# Altium schematic sheet power.SchDoc
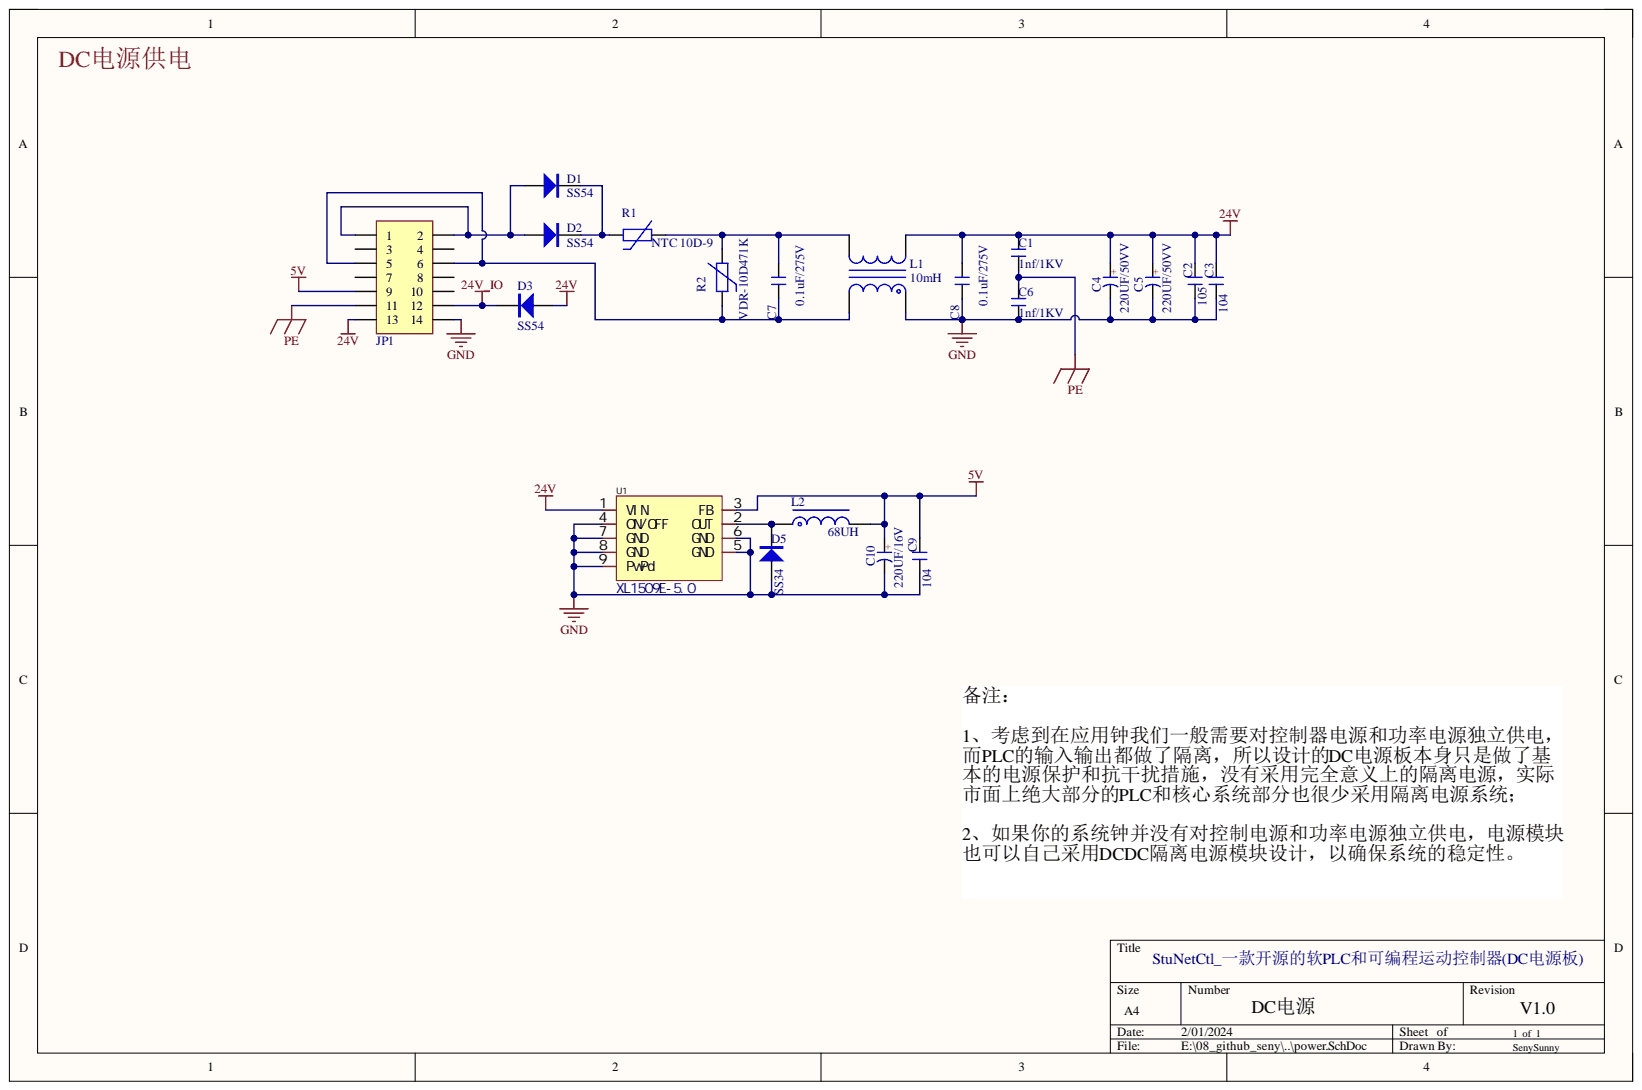
[diagram p0: power.SchDoc]

## Parts
C1  1nf/1KV  [CY 7.5*8*4]
C2  105  [C 0805]
C3  104  [C 0805]
C4  220UF/50VV  [CAP 5.0*10*12.5 - BK]
C5  220UF/50VV  [CAP 5.0*10*12.5 - BK]
C6  1nf/1KV  [CY 7.5*8*4]
C7  0.1uF/275V  [CX 10*13*11]
C8  0.1uF/275V  [CX 10*13*11]
C9  104  [C 0805]
C10  220UF/16V  [CAP 2.5*6.3*11.2 - BK]
D1  SS54  [SMA(DO214AC)]
D2  SS54  [SMA(DO214AC)]
D3  SS54  [SMA(DO214AC)]
D5  SS34  [SMA(DO214AC)]
JP1  Header 2X7  [HDR-TH_14P-P2.54-V-R2-C7-S2.54]  (value not drawn)
L1  10mH  [UU9.8]
L2  68UH  [CDRH127]
R1  NTC 10D-9  [NTC XD-9]
R2  VDR-10D471K  [VDR 10DX]
U1  XL1509E-5.0  [SOP8P_L]

## Nets
5V: C9.2 C10.1 JP1.9 L2.2 U1.3(FB)
24V: C1.2 C2.2 C3.2 C4.1 C5.1 C8.2 D3.1 JP1.13 L1.4 U1.1(VIN)
24V_IO: D3.2 JP1.12
GND: C2.1 C3.1 C4.2 C5.2 C6.1 C8.1 C9.1 C10.2 D5.1 JP1.14 L1.1 U1.4(ON/OFF) U1.5(GND) U1.6(GND) U1.7(GND) U1.8(GND) U1.9(PwPd)
PE: C1.1 C6.2 JP1.11
NetC7_1: C7.1 JP1.5 JP1.6 L1.2 R2.1
NetC7_2: C7.2 L1.3 R1.2 R2.2
NetD1_1: D1.1 D2.1 JP1.1 JP1.2
NetD1_2: D1.2 D2.2 R1.1
NetD5_2: D5.2 L2.1 U1.2(OUT)

Not connected: JP1 3 4 7 8 10

## Library data of the parts
C1 C6 = C-CY: 高压陶瓷电容，Y电容; 版权归属: 源创客; BOM名称: 高压陶瓷电容，Y电容; Component Kind: Standard; 学习资源: www.ooocke.com; Library Name: zblib_v1.IntLib
C2 C3 C9 = C: 无极性贴片电容; 版权归属: 源创客; BOM名称: 无极性贴片电容; Component Kind: Standard; 学习资源: www.ooocke.com; Library Name: zblib_v1.IntLib
C4 C5 C10 = C-CAP: 直插电解电容; 版权归属: 源创客; BOM名称: 直插电解电容; Component Kind: Standard; 学习资源: www.ooocke.com; Library Name: zblib_v1.IntLib
C7 C8 = C-CX: X电容; 版权归属: 源创客; BOM名称: X电容; Component Kind: Standard; 学习资源: www.ooocke.com; Library Name: zblib_v1.IntLib
D1-D3 D5 = SS14: 肖特基二极管; 属性: 40V/1A; 版权归属: 源创客; BOM名称: 肖特基二极管; Component Kind: Standard; 学习资源: www.ooocke.com; Library Name: zblib_v1.IntLib
JP1 = Header 7X2: 7*2P接插件; 版权归属: 源创客; BOM名称: 7*2P接插件; Component Kind: Standard; 学习资源: www.ooocke.com; Library Name: zblib_v1.IntLib
L1 = L-CMC: 共模电感; 版权归属: 源创客; BOM名称: 共模电感; Component Kind: Standard; 学习资源: www.ooocke.com; Library Name: zblib_v1.IntLib
L2 = L-CDRH: 功率屏蔽电感; 版权归属: 源创客; BOM名称: 功率屏蔽电感; Component Kind: Standard; 学习资源: www.ooocke.com; Library Name: zblib_v1.IntLib
R1 R2 = R_VDR: 压敏电阻; 版权归属: 源创客; BOM名称: 压敏电阻; Component Kind: Standard; 学习资源: www.ooocke.com; Library Name: zblib_v1.IntLib
U1 = XL1509E-5.0: DC-DC电源芯片; Manufacturer: UMW(广东友台半导体); Add into BOM: yes; Device: XL1509-5.0; Symbol: XL1509-5.0_C2902368; Component Kind: Standard; Convert to PCB: yes; Datasheet: https://atta.szlcsc.com/upload/public/pdf/source/20230412/A4F395BE4384B04485E6C784EB623363.pdf; JLCPCB Part Class: Extended Part; LCSC Part Name: XL1509-5.0; Manufacturer Part: XL1509-5.0; Name: XL1509-5.0; Supplier: LCSC; Supplier Footprint: SOIC-8; Supplier Part: C2902368; Library Name: zblib_v1.IntLib
